# Altium schematic sheet timer_tigresse.SchDoc
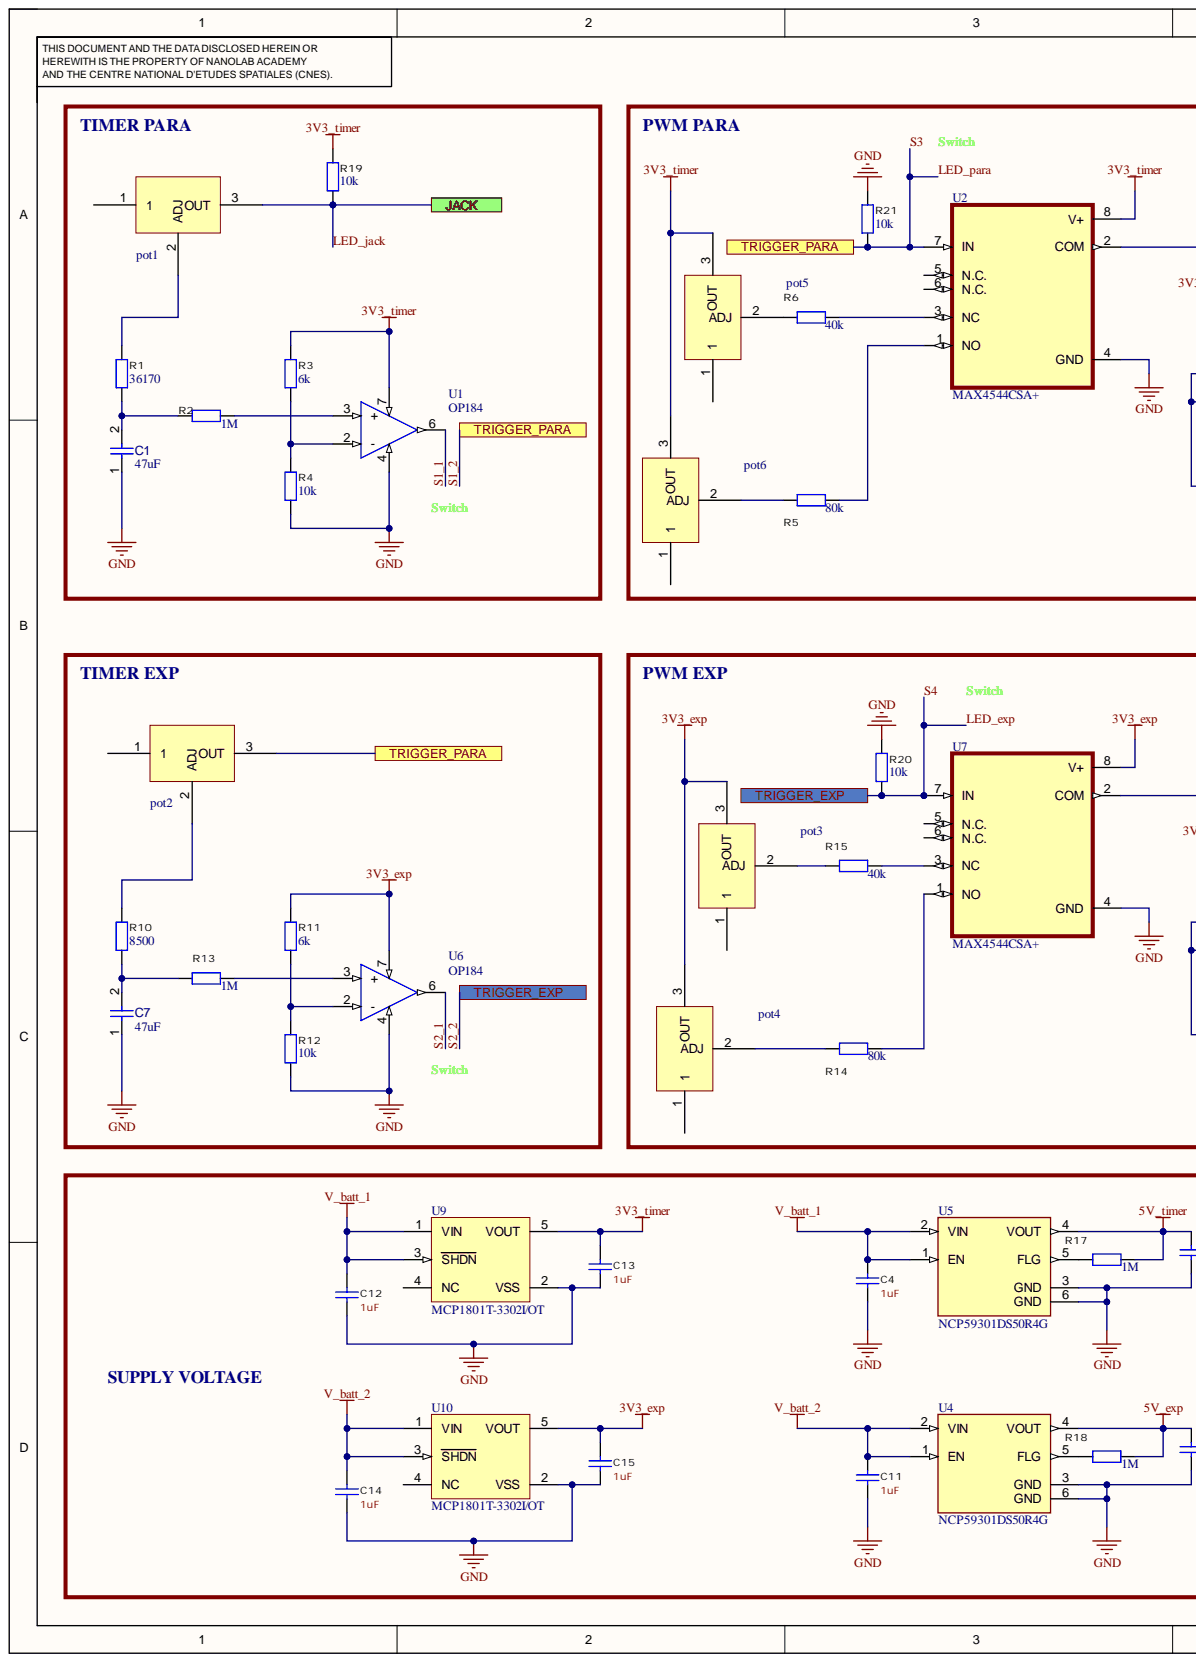
[diagram p0: timer_tigresse.SchDoc, left]
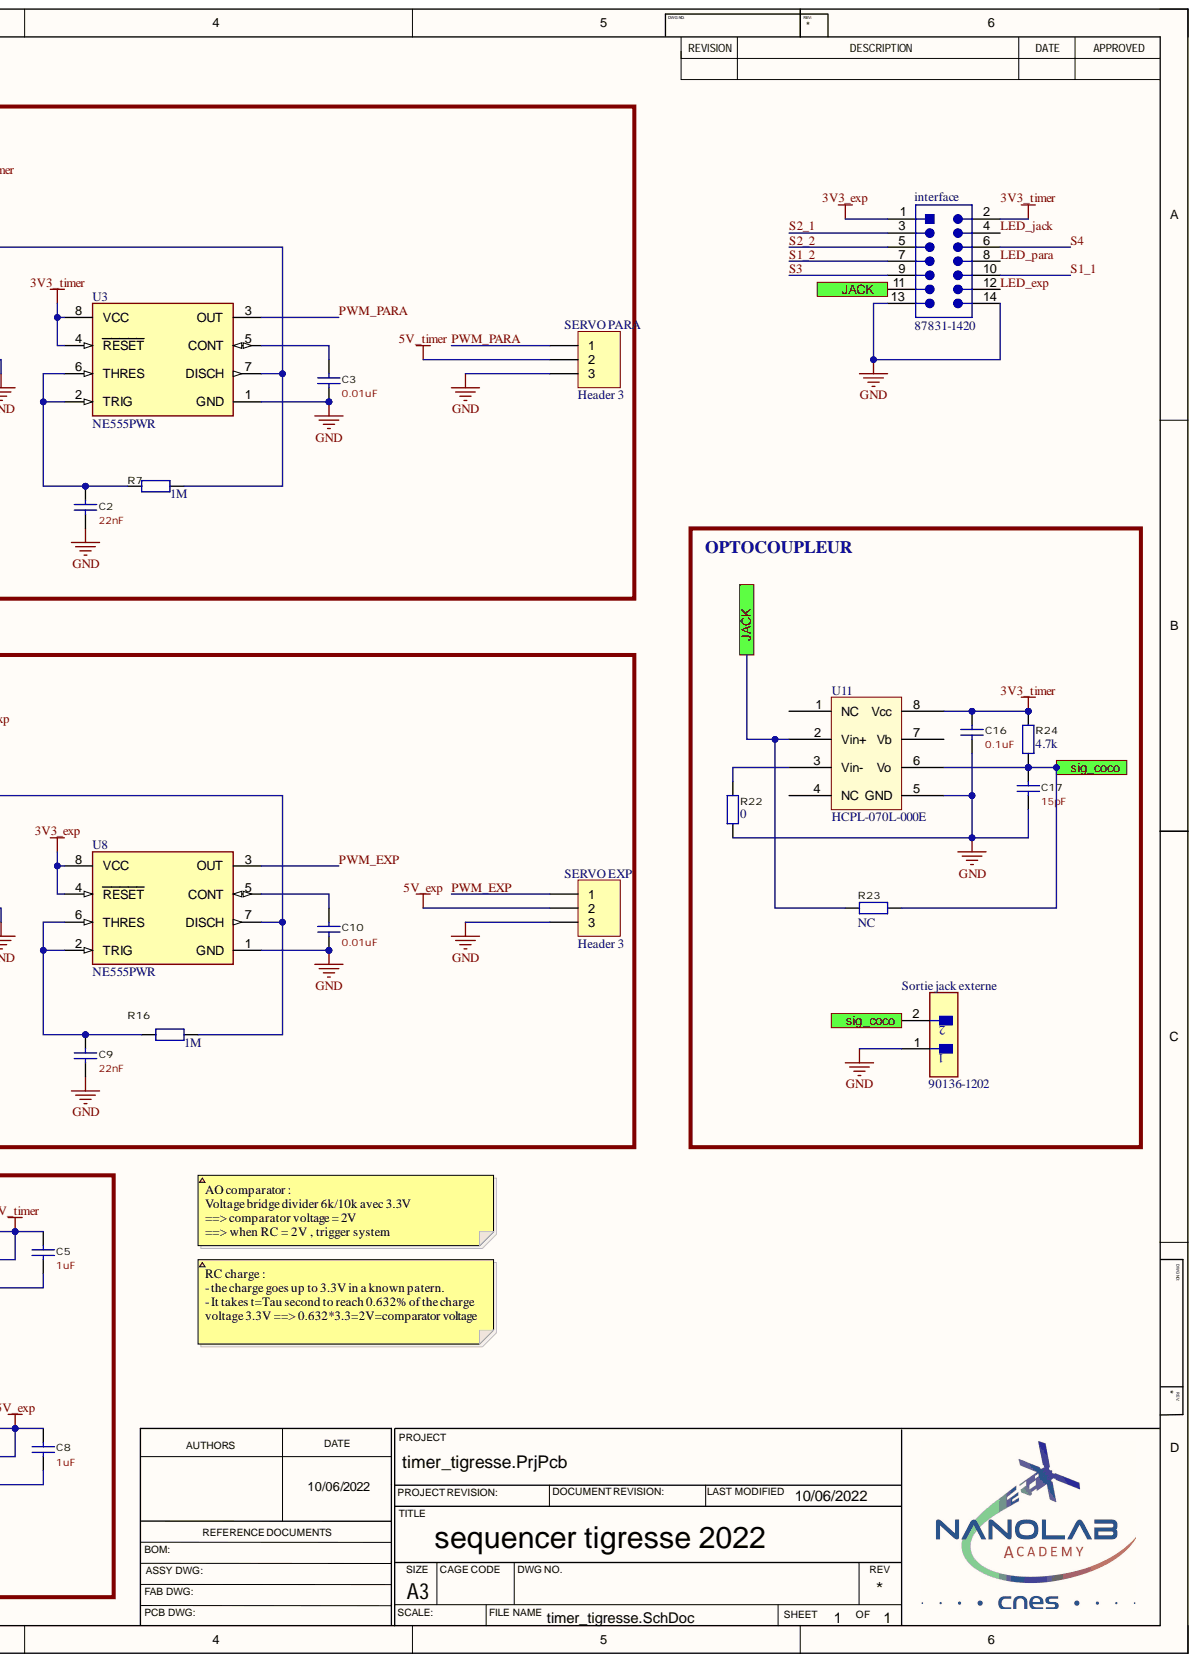
[diagram p1: timer_tigresse.SchDoc, right]

## Parts
C1  value 47uF  [CAPC2013X135X45NL10T25]  (hidden comment: Capacitor 10 uF +/- 10% 16 V 0805)
C2  value 22nF  [0603c]  (hidden comment: C0603C104K4PACTU)
C3  value 0.01uF  [0603c]  (hidden comment: C0603C104K4PACTU)
C4  value 1uF  [0603c]  (hidden comment: C0603C104K4PACTU)
C5  value 1uF  [0603c]  (hidden comment: C0603C104K4PACTU)
C7  value 47uF  [CAPC2013X135X45NL10T25]  (hidden comment: Capacitor 10 uF +/- 10% 16 V 0805)
C8  value 1uF  [0603c]  (hidden comment: C0603C104K4PACTU)
C9  value 22nF  [0603c]  (hidden comment: C0603C104K4PACTU)
C10  value 0.01uF  [0603c]  (hidden comment: C0603C104K4PACTU)
C11  value 1uF  [0603c]  (hidden comment: C0603C104K4PACTU)
C12  value 1uF  [0603c]  (hidden comment: C0603C104K4PACTU)
C13  value 1uF  [0603c]  (hidden comment: C0603C104K4PACTU)
C14  value 1uF  [0603c]  (hidden comment: C0603C104K4PACTU)
C15  value 1uF  [0603c]  (hidden comment: C0603C104K4PACTU)
C16  value 0.1uF  [0603c]  (hidden comment: C0603C104K4PACTU)
C17  value 15pF  [0603c]  (hidden comment: C0603C104K4PACTU)
interface  87831-1420  [MOLEX-87831-1420_V]
pot1  3386F-1-153LF  [New footprint 1]  (value not drawn)
pot2  3386F-1-153LF  [New footprint 1]  (value not drawn)
pot3  3386F-1-153LF  [New footprint 1]  (value not drawn)
pot4  3386F-1-153LF  [New footprint 1]  (value not drawn)
pot5  3386F-1-153LF  [New footprint 1]  (value not drawn)
pot6  3386F-1-153LF  [New footprint 1]  (value not drawn)
R1  value 36170  [0805]  (hidden comment: ERJ-P06F1003V)
R2  value 1M  [0805]  (hidden comment: ERJ-P06F1003V)
R3  value 6k  [0805]  (hidden comment: ERJ-P06F1003V)
R4  value 10k  [0805]  (hidden comment: ERJ-P06F1003V)
R5  value 80k  [0805]  (hidden comment: ERJ-P06F1003V)
R6  value 40k  [0805]  (hidden comment: ERJ-P06F1003V)
R7  value 1M  [0805]  (hidden comment: ERJ-P06F1003V)
R10  value 8500  [0805]  (hidden comment: ERJ-P06F1003V)
R11  value 6k  [0805]  (hidden comment: ERJ-P06F1003V)
R12  value 10k  [0805]  (hidden comment: ERJ-P06F1003V)
R13  value 1M  [0805]  (hidden comment: ERJ-P06F1003V)
R14  value 80k  [0805]  (hidden comment: ERJ-P06F1003V)
R15  value 40k  [0805]  (hidden comment: ERJ-P06F1003V)
R16  value 1M  [0805]  (hidden comment: ERJ-P06F1003V)
R17  value 1M  [0805]  (hidden comment: ERJ-P06F1003V)
R18  value 1M  [0805]  (hidden comment: ERJ-P06F1003V)
R19  value 10k  [0805]  (hidden comment: ERJ-P06F1003V)
R20  value 10k  [0805]  (hidden comment: ERJ-P06F1003V)
R21  value 10k  [0805]  (hidden comment: ERJ-P06F1003V)
R22  value 0  [0805]  (hidden comment: ERJ-P06F1003V)
R23  value NC  [0805]  (hidden comment: ERJ-P06F1003V)
R24  value 4.7k  [0805]  (hidden comment: ERJ-P06F1003V)
SERVO_EXP  Header 3  [HDR1X3]
SERVO_PARA  Header 3  [HDR1X3]
Sortie_jack_externe  90136-1202  [FP-90136-1202-MFG]
U1  OP184  [OPA184-Footprint-1]
U2  MAX4544CSA+  [New footprint 1]
U3  NE555PWR  [FP-PW0008A-MFG]
U4  NCP59301DS50R4G  [New footprint 1]
U5  NCP59301DS50R4G  [New footprint 1]
U6  OP184  [OPA184-Footprint-1]
U7  MAX4544CSA+  [New footprint 1]
U8  NE555PWR  [FP-PW0008A-MFG]
U9  MCP1801T-3302I/OT  [SOT-23-OT5_N]
U10  MCP1801T-3302I/OT  [SOT-23-OT5_N]
U11  HCPL-070L-000E  [HCPL-070L-000E-Footprint-1]

## Ports
JACK, sig_coco, TRIGGER_EXP, TRIGGER_PARA

## Nets
3V3_exp: C15.1 interface.1 pot3.3 pot4.3 R11.2 U6.7 U7.8(V+) U8.4(RESET) U8.8(VCC) U10.5(VOUT)
3V3_timer: C13.1 C16.1 interface.2 pot5.3 pot6.3 R3.2 R19.2 R24.2 U1.7 U2.8(V+) U3.4(RESET) U3.8(VCC) U9.5(VOUT) U11.8(Vcc)
5V_exp: C8.1 R18.2 SERVO_EXP.2 U4.4(VOUT)
5V_timer: C5.1 R17.2 SERVO_PARA.2 U5.4(VOUT)
GND: C1.1 C2.2 C3.2 C4.2 C5.2 C7.1 C8.2 C9.2 C10.2 C11.2 C12.2 C13.2 C14.2 C15.2 C16.2 C17.2 interface.13 interface.14 R4.1 R12.1 R20.1 R21.1 R22.1 SERVO_EXP.3 SERVO_PARA.3 Sortie_jack_externe.1 U1.4 U2.4(GND) U3.1(GND) U4.3(GND) U4.6(GND) U5.3(GND) U5.6(GND) U6.4 U7.4(GND) U8.1(GND) U9.2(VSS) U10.2(VSS) U11.5(GND)
V_batt_1: C4.1 C12.1 U5.1(EN) U5.2(VIN) U9.1(VIN) U9.3(SHDN)
V_batt_2: C11.1 C14.1 U4.1(EN) U4.2(VIN) U10.1(VIN) U10.3(SHDN)
LED_exp: interface.5 interface.6 interface.12 R20.2 U7.7(IN) ; port TRIGGER_EXP
LED_jack: interface.4 interface.11 pot1.3 R19.1 R23.2 U11.2(Vin+) ; port JACK
LED_para: interface.7 interface.8 interface.9 pot2.3 R21.2 U2.7(IN) ; port TRIGGER_PARA
PWM_EXP: SERVO_EXP.1 U8.3(OUT)
PWM_PARA: SERVO_PARA.1 U3.3(OUT)
S1_1: interface.10 U1.6
S2_1: interface.3 U6.6
sig_coco: C17.1 R23.1 R24.1 Sortie_jack_externe.2 U11.6(Vo) ; port sig_coco
NetC1_2: C1.2 R1.1 R2.1
NetC2_1: C2.1 R7.1 U3.2(TRIG) U3.6(THRES)
NetC3_1: C3.1 U3.5(CONT)
NetC7_2: C7.2 R10.1 R13.1
NetC9_1: C9.1 R16.1 U8.2(TRIG) U8.6(THRES)
NetC10_1: C10.1 U8.5(CONT)
Netpot1_2: pot1.2 R1.2
Netpot2_2: pot2.2 R10.2
Netpot3_2: pot3.2 R15.1
Netpot4_2: pot4.2 R14.1
Netpot5_2: pot5.2 R6.1
Netpot6_2: pot6.2 R5.1
NetR2_2: R2.2 U1.3(+)
NetR3_1: R3.1 R4.2 U1.2(-)
NetR5_2: R5.2 U2.1(NO)
NetR6_2: R6.2 U2.3(NC)
NetR7_2: R7.2 U2.2(COM) U3.7(DISCH)
NetR11_1: R11.1 R12.2 U6.2(-)
NetR13_2: R13.2 U6.3(+)
NetR14_2: R14.2 U7.1(NO)
NetR15_2: R15.2 U7.3(NC)
NetR16_2: R16.2 U7.2(COM) U8.7(DISCH)
NetR17_1: R17.1 U5.5(FLG)
NetR18_1: R18.1 U4.5(FLG)
NetR22_2: R22.2 U11.3(Vin-)

Not connected: pot1 1; pot2 1; pot3 1; pot4 1; pot5 1; pot6 1; U2 5(N.C.) 6(N.C.); U7 5(N.C.) 6(N.C.); U9 4(NC); U10 4(NC); U11 1(NC) 4(NC) 7(Vb)

## Library data of the parts
C1 C7 = CMP-001-00015-6: Chip Capacitor, 10 uF, +/- 10%, 16 V, 0805 (2012 Metric); Capacitor Type: Ceramic; Voltage Rating: 16V; Case/Package: 0805; Component Kind: Standard; Max Operating Temperature: 85°C; Min Operating Temperature: -55°C; Mounting Technology: SM; Pins: 2; RoHS Compliant: True; Tolerance: 10%; Library Name: NANOLAB Academy
C2-C5 C8-C17 = CMP-001-00102-3: Cap Ceramic 0.1uF 16V X5R 10% SMD 0603 85°C Paper T/R; Component Kind: Standard; Library Name: CNES Centre Spatial de Toulouse
interface = CMP-002-00111-1: 2.00mm Pitch Milli-Grid Header Vertical Through Hole Shrouded 14 Circuits 0.38; Component Kind: Standard; Library Name: NANOLAB Academy
pot1-pot6 = CMP-009-00259-1: Trimmer, Cermet, 0.5W, 15000ohm, 10% +/-Tol, 100ppm/Cel, 1 Turn(s); Component Kind: Standard; Library Name: NANOLAB Academy
R1-R7 R10-R24 = CMP-009-00217-1: PANASONIC - Res Thick Film 0805 100K Ohm 1% 1/2W ±100ppm/C Molded SMD Punched Carrier T/R; Component Kind: Standard; Library Name: CNES Centre Spatial de Toulouse
SERVO_EXP SERVO_PARA = Header 3: Header, 3-Pin; Component Kind: Standard; LatestRevisionDate: 17-Jul-2002; LatestRevisionNote: Re-released for DXP Platform.; Library Name: Miscellaneous Connectors.IntLib; Signal Integrity: Connector; Publisher: Altium Limited
Sortie_jack_externe = CMP-002-00113-1: Conn Shrouded Header (4 Sides) HDR 2 POS 2.54mm Solder ST Thru-Hole C-Grid III™ Frame; Component Kind: Standard; Library Name: NANOLAB Academy
U1 U6 = CMP-001-0000-3: ANALOG DEVICES - Precision Rail-to-Rail Input and Output Operational Amplifiers - SOIC-8; Component Kind: Standard; Library Name: NANOLAB Academy
U2 U7 = CMP-015-00012-1: Low-Voltage, Single-Supply Dual SPST/SPDT Analog Switches; Component Kind: Standard; Library Name: NANOLAB Academy
U3 U8 = CMP-00001-1: Single Precision Timer 8-TSSOP 0 to 70; Frequency: 100kHz; High Level Output Current: 200mA; Low Level Output Current: -200mA; Operating Supply Current: 2mA; Case/Package: TSSOP; Component Kind: Standard; Lead Free: Lead Free; Max Operating Temperature: 70°C; Min Operating Temperature: 0°C; Mount: Surface Mount; Number of Pins: 8; Packaging: Cut Tape; RoHS Compliant: Yes; Series: NE555; Library Name: NANOLAB Academy
U4 U5 = CMP-019-00058-1: Régulateur LDO Tension fixe, entrée 2,24 V à 13,5 V, Dropout 400 mV, Sortie 5 V, 3 A, TO-263-5; Component Kind: Standard; Library Name: NANOLAB Academy
U9 U10 = CMP-019-00059-1: IC, LINEAR REGULATOR;  MAX. INPUT, 10V;  OUTPUT, 3.3V;  150MA;  ACCURACY, 0.4%;  SOT23-5; Component Kind: Standard; Library Name: NANOLAB Academy
U11 = CMP-015-00014-1: Optocoupler DC-IN 1-CH Darlington With Base DC-OUT 8-Pin SOIC Tube; Component Kind: Standard; Library Name: NANOLAB Academy
